# Altium schematic sheet DS1307.SchDoc
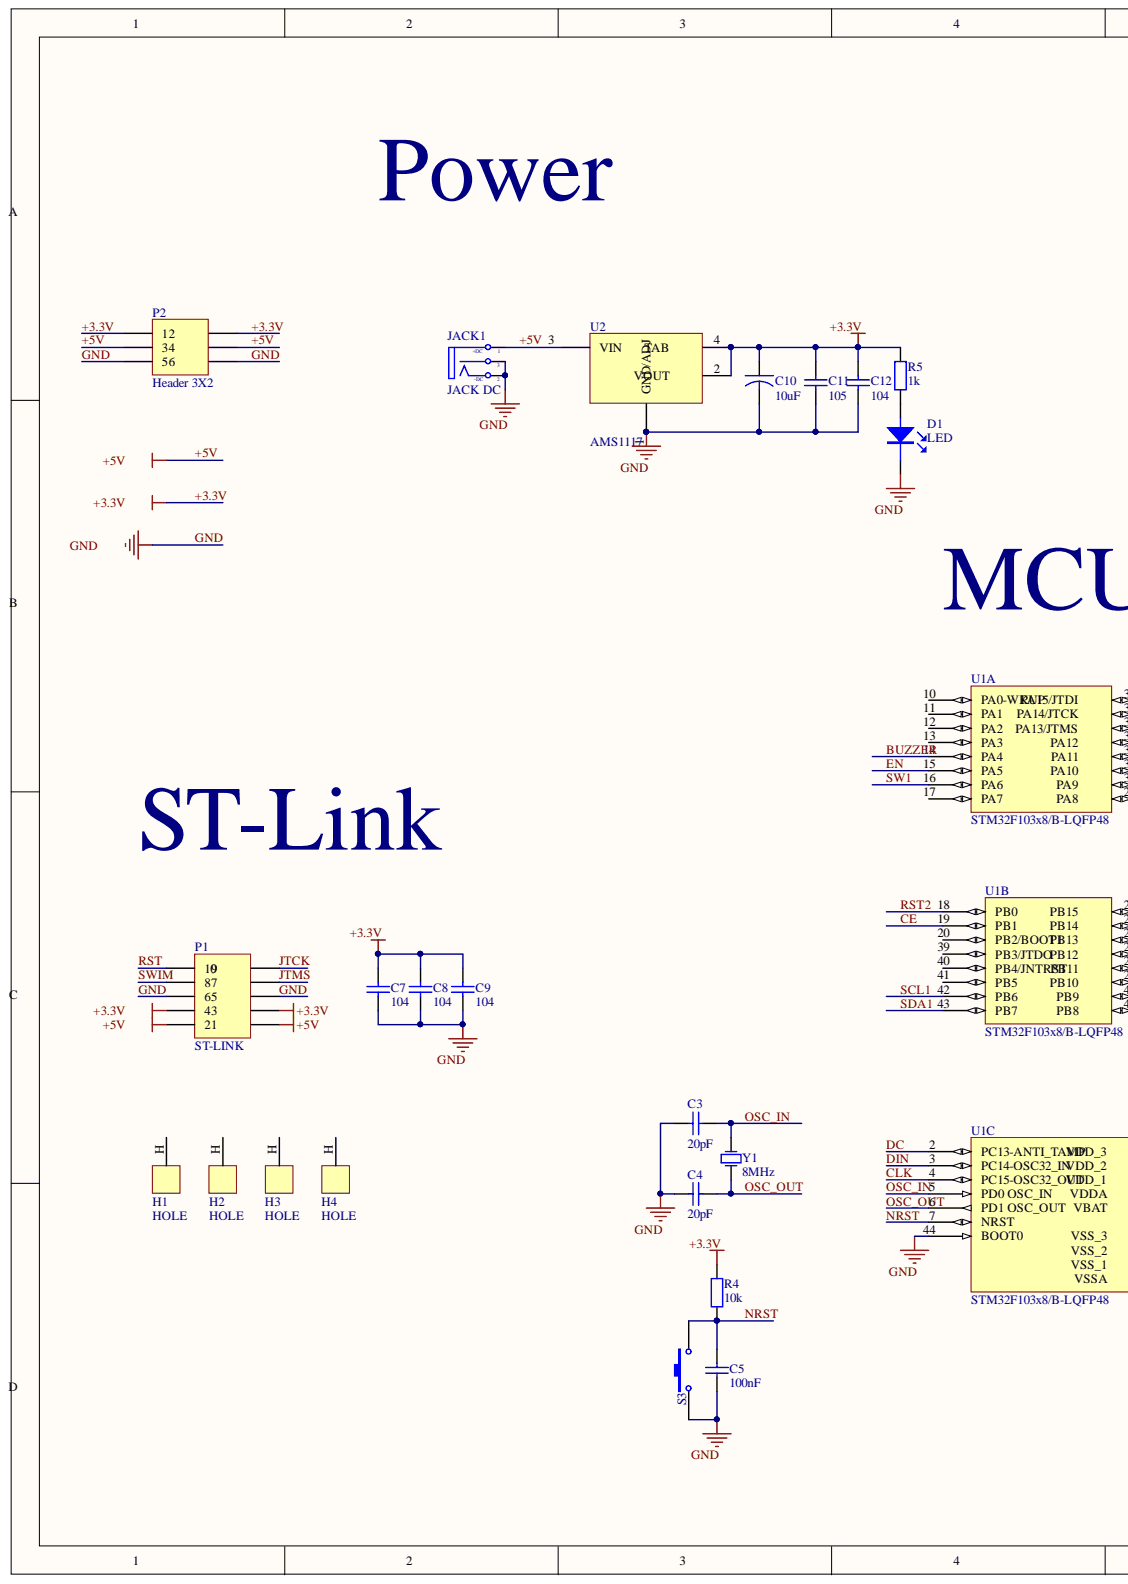
[diagram p0: DS1307.SchDoc, left]
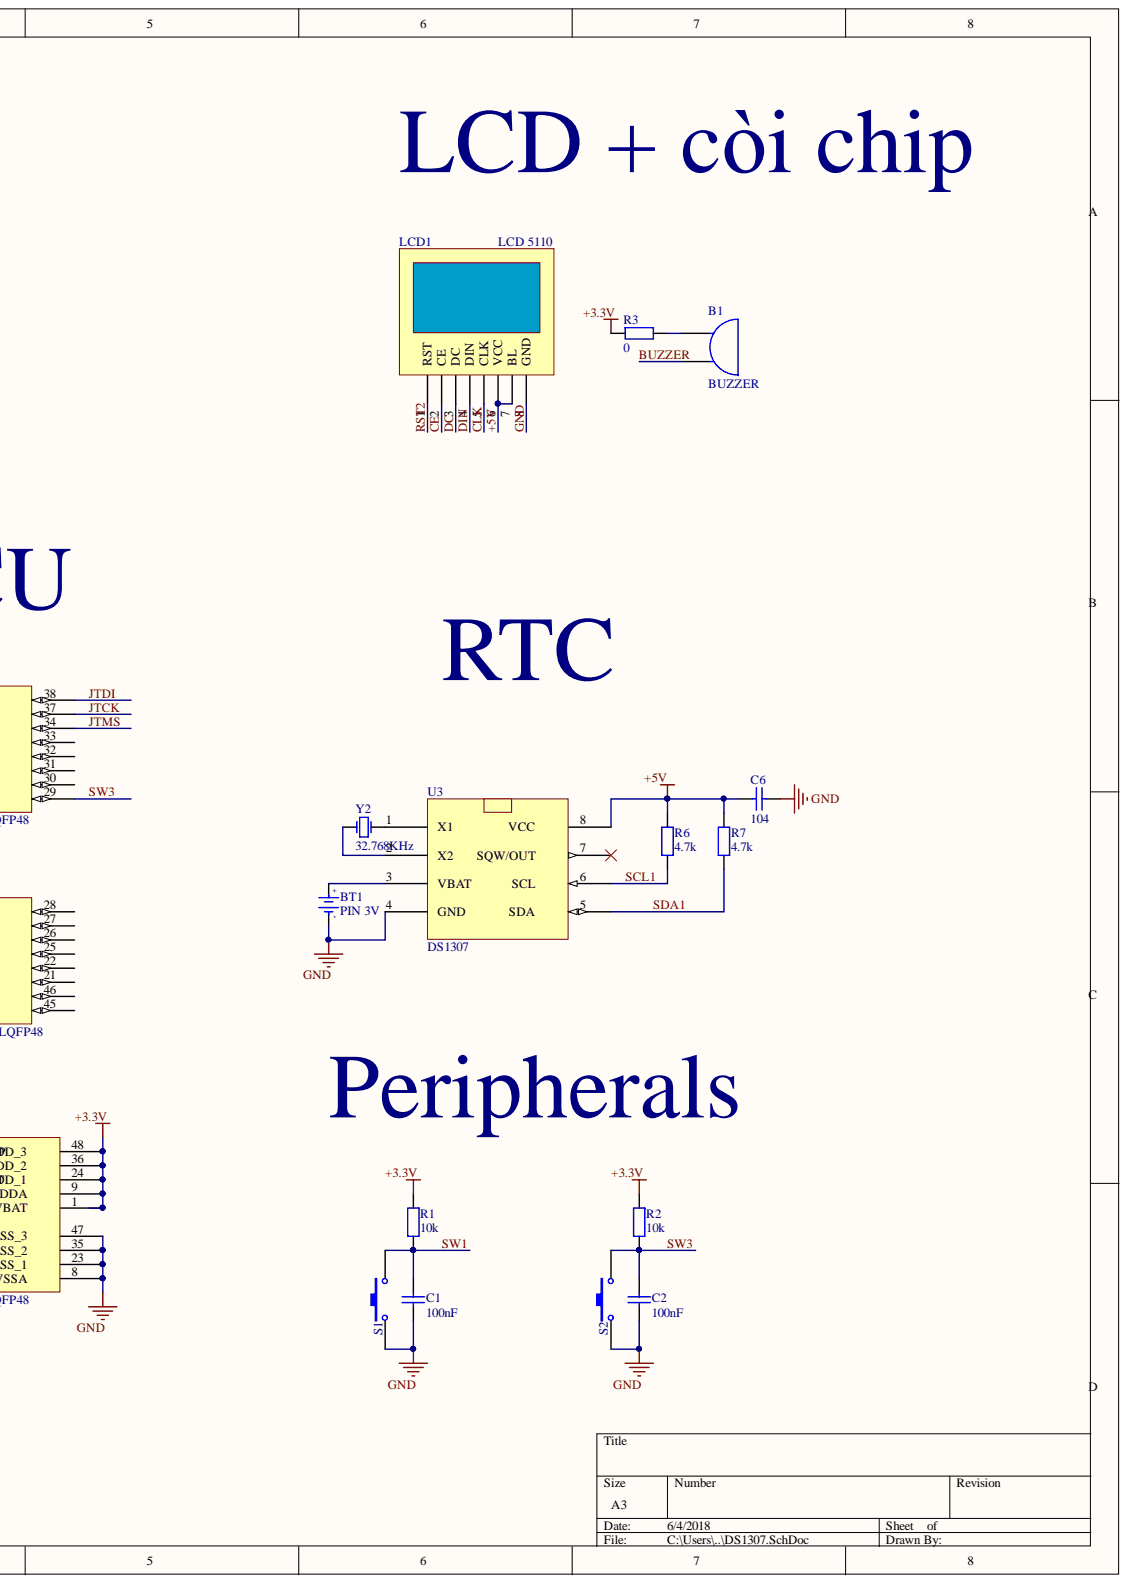
[diagram p1: DS1307.SchDoc, right]

## Parts
B1  BUZZER  [SPEAKER]
BT1  PIN 3V  [PIN3V]
C1  100nF  [0805 CAP]
C2  100nF  [0805 CAP]
C3  20pF  [0805 CAP]
C4  20pF  [0805 CAP]
C5  100nF  [0805 CAP]
C6  104  [0805 CAP]
C7  104  [0805 CAP]
C8  104  [0805 CAP]
C9  104  [0805 CAP]
C10  10uF  [CAPMP3216X16N]
C11  105  [0805 CAP]
C12  104  [0805 CAP]
D1  LED  [LED SMD 0805]
H1  HOLE  [HOLE]
H2  HOLE  [HOLE]
H3  HOLE  [HOLE]
H4  HOLE  [HOLE]
JACK1  JACK DC  [JACK DC]
LCD1  LCD 5110  [LCD 5110]
P1  ST-LINK  [IDC10 MALE]
P2  Header 3X2  [HDR2X3]
R1  10k  [0805 RESISTOR]
R2  10k  [0805 RESISTOR]
R3  0  [0805 RESISTOR]
R4  10k  [0805 RESISTOR]
R5  1k  [0805 RESISTOR]
R6  4.7k  [0805 RESISTOR]
R7  4.7k  [0805 RESISTOR]
S1  (no value)  [SW 4PIN]
S2  (no value)  [SW 4PIN]
S3  (no value)  [SW 4PIN]
U1  STM32F103x8/B-LQFP48  [LQFP 48 PIN]  (parts A,B,C)
U2  AMS1117  [SOT223 - 3 LEAD]
U3  DS1307  [SOIC 8PIN]
Y1  8MHz  [CRYSTAL DIP]
Y2  32.768KHz  [XTAL 32kHz]

## Nets
+3.3V: C7.2 C8.2 C9.2 C10.1 C11.2 C12.2 P1.3 P1.4(4_3) P2.1 P2.2 R1.1 R2.1 R3.1 R4.1 R5.2 U1.1 U1.9 U1.24 U1.36 U1.48 U2.2 U2.4
+5V: C6.2 JACK1.1 LCD1.6(VCC) LCD1.7(BL) P1.1 P1.2(2_1) P2.3 P2.4 R6.2 R7.2 U2.3(VIN) U3.8
BUZZER: B1.2 U1.14(PA4)
CE: LCD1.2(CE) U1.19(PB1)
CLK: LCD1.5(CLK) U1.4(PC15-OSC32_OUT)
DC: LCD1.3(DC) U1.2(PC13-ANTI_TAMP)
DIN: LCD1.4(DIN) U1.3(PC14-OSC32_IN)
EN: U1.15(PA5)
GND: BT1.2 C1.2 C2.2 C3.1 C4.1 C5.2 C6.1 C7.1 C8.1 C9.1 C10.2 C11.1 C12.1 D1.2 JACK1.2 JACK1.3 LCD1.8(GND) P1.5 P1.6(6_5) P2.5 P2.6 S1.1 S2.1 S3.1 U1.8 U1.23 U1.35 U1.44(BOOT0) U1.47 U2.1(GND/ADJ) U3.4(GND)
JTCK: P1.9 U1.37
JTDI: U1.38
JTMS: P1.7 U1.34
NRST: C5.1 R4.2 S3.2 U1.7(NRST)
OSC_IN: C3.2 U1.5(PD0_OSC_IN) Y1.2
OSC_OUT: C4.2 U1.6(PD1_OSC_OUT) Y1.1
RST: P1.10
RST2: LCD1.1(RST) U1.18(PB0)
SCL1: R6.1 U1.42(PB6) U3.6
SDA1: R7.1 U1.43(PB7) U3.5
SW1: C1.1 R1.2 S1.2 U1.16(PA6)
SW3: C2.1 R2.2 S2.2 U1.29
SWIM: P1.8(8_7)
NetB1_1: B1.1 R3.2
NetBT1_1: BT1.1 U3.3(VBAT)
NetD1_1: D1.1 R5.1
NetU3_1: U3.1(X1) Y2.1
NetU3_2: U3.2(X2) Y2.2

Not connected: H1 H; H2 H; H3 H; H4 H; U1 10(PA0-WKUP) 11(PA1) 12(PA2) 13(PA3) 17(PA7) 20(PB2/BOOT1) 21 22 25 26 27 28 30 31 32 33 39(PB3/JTDO) 40(PB4/JNTRST) 41(PB5) 45 46; U3 7

## Library data of the parts
C1-C9 C11 C12 = CAP CERAMIC: Component Kind: Standard; LatestRevisionDate: 17-Jul-2002; LatestRevisionNote: Re-released for DXP Platform.; PackageReference: RAD-0.3; Published: 8-Jun-2000; Publisher: Altium Limited
  C1 C2 C5 C7-C9 C11 C12: Library Name: THINHDUILIB.SchLib
  C3 C4 C6: Library Name: ThinhNguyenLib.SchLib
C10 = CAPACITOR: Component Kind: Standard; Library Name: THINHDUILIB.SchLib
JACK1 = JACK DC: Value: Jac DC; Component Kind: Standard; Library Name: THINHDUILIB.SchLib
P1 = Header 5X2: Component Kind: Standard; LatestRevisionDate: 17-Jul-2002; LatestRevisionNote: Re-released for DXP Platform.; Library Name: ThinhNguyenLib.SchLib; Publisher: Altium Limited
R1-R7 = RESISTOR: Value: R1/4W - 1K; Component Kind: Standard
  R1 R2 R4 R5: Library Name: THINHDUILIB.SchLib
  R3 R6 R7: Library Name: ThinhNguyenLib.SchLib
S1-S3 = SWITCH: Component Kind: Standard; LatestRevisionDate: 17-Jul-2002; LatestRevisionNote: Re-released for DXP Platform.; PackageReference: SPST-2; Published: 24-Mar-1999; Library Name: THINHDUILIB.SchLib; Publisher: Altium Limited
Y1 Y2 = Crystal: Component Kind: Standard; LatestRevisionDate: 17-Jul-2002; LatestRevisionNote: Re-released for DXP Platform.; PackageReference: R38; Published: 8-Jun-2000; Library Name: ThinhNguyenLib.SchLib; Publisher: Altium Limited
B1 = BUZZER: Component Kind: Standard; Library Name: ThinhNguyenLib.SchLib
BT1 = PIN 3V: Component Kind: Standard; Value: PIN 3V; Library Name: ThinhNguyenLib.SchLib
D1 = LED: Component Kind: Standard; Library Name: THINHDUILIB.SchLib
H1-H4 = HOLE: Component Kind: Standard; Library Name: THINHDUILIB.SchLib
LCD1 = LCD 5110: Component Kind: Standard; Library Name: ThinhNguyenLib.SchLib
P2 = Header 3X2: Component Kind: Standard; LatestRevisionDate: 17-Jul-2002; LatestRevisionNote: Re-released for DXP Platform.; Signal Integrity: Connector; Library Name: ThinhNguyenLib.SchLib; Publisher: Altium Limited
U1 = STM32F103x8/B-LQFP48: Component Kind: Standard; ComponentLink1Description: Manufacturer Link; ComponentLink1URL: www.st.com/stm32; ComponentLink2Description: Datasheet; ComponentLink2URL: http://www.st.com/stonline/products/literature/ds/13586.pdf; DatasheetVersion: rev6, Mar-2008; PackageDescription: 48-Pin Low-Profile Quad Flat Pack, 7 x 7 mm Body, 0.5 mm Pitch (LQFP48); PackageReference: LQFP48; PackageVersion: Mar-2008; Published: 30-May-2008; Library Name: ThinhNguyenLib.SchLib; Publisher: Altium Limited
U2 = AMS1117: Component Kind: Standard; Library Name: THINHDUILIB.SchLib
U3 = DS1307: Component Kind: Standard; Library Name: ThinhNguyenLib.SchLib
